# Altium schematic sheet stm32core.SchDoc
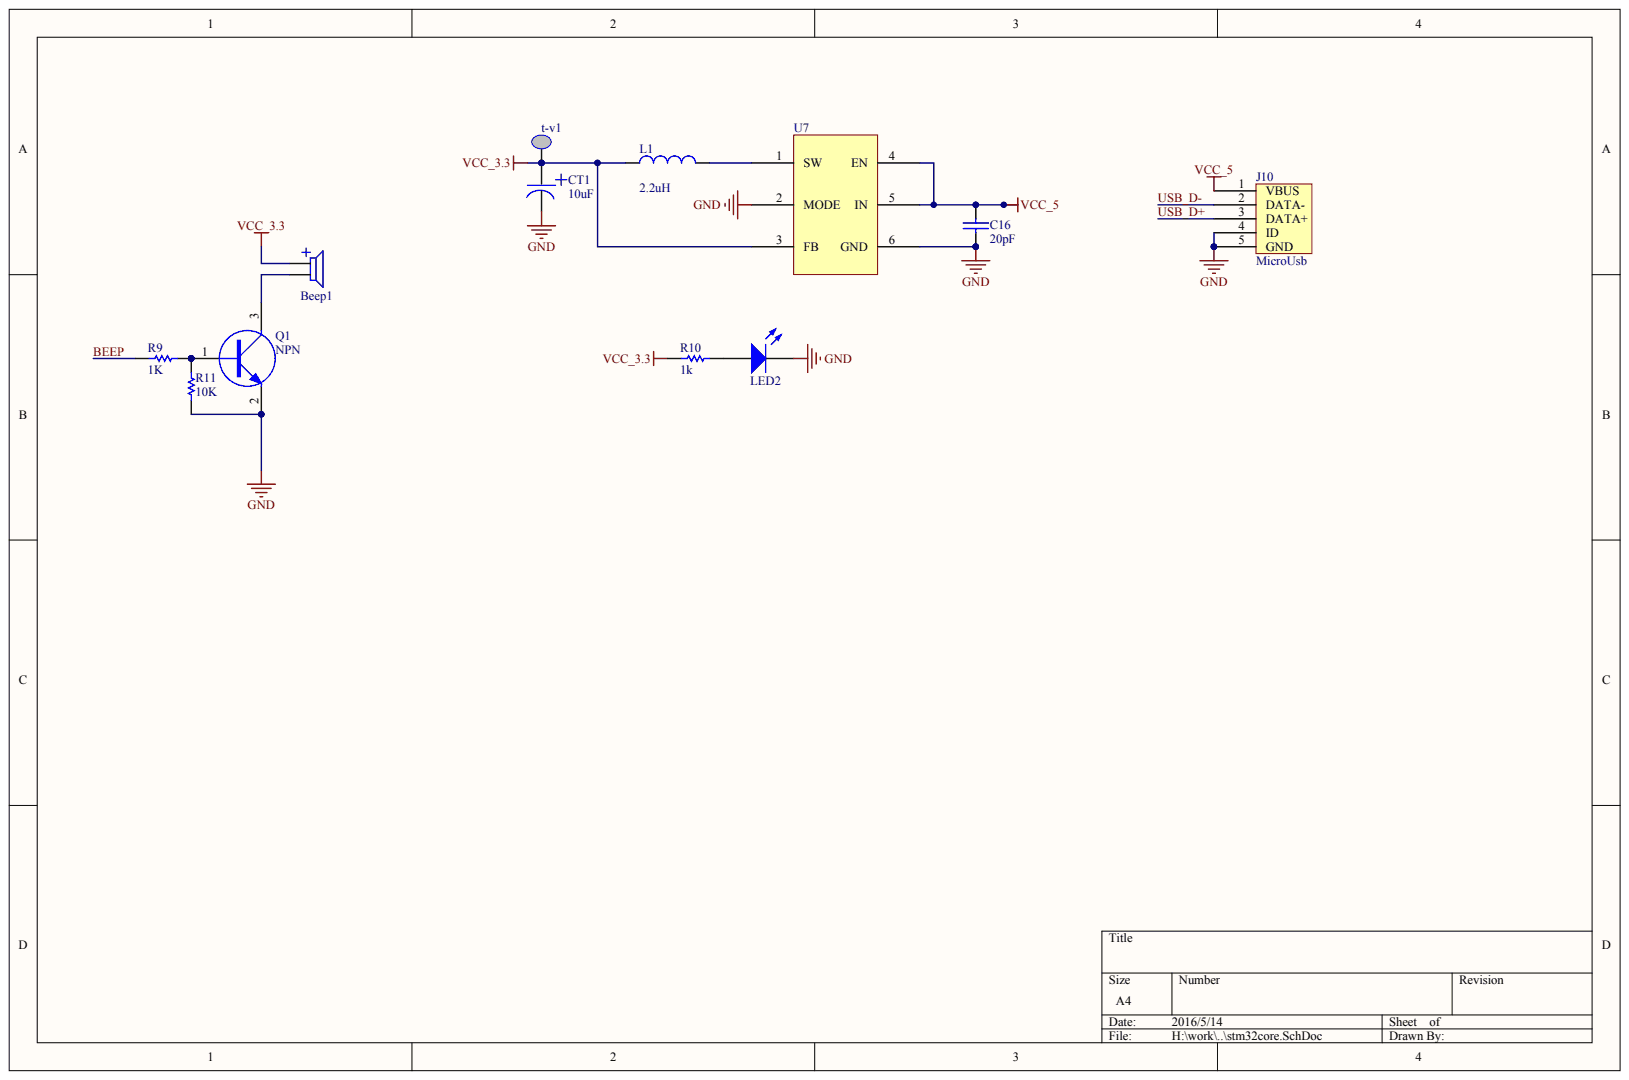
[diagram p0: stm32core.SchDoc]

## Parts
Beep1  Beep
C16  20pF
CT1  10uF
J10  MicroUsb
L1  2.2uH
LED2  LED
Q1  NPN
R9  1K
R10  1k
R11  10K
t-v1  TestPoint  (value not drawn)
U7  U?

## Nets
GND: C16.2 CT1.2 J10.4(ID) J10.5(GND) LED2.2 Q1.2 R11.1 U7.2(MODE) U7.6(GND)
VCC_3.3: Beep1.1 CT1.1 L1.1 R10.2 t-v1.1 U7.3(FB)
VCC_5: C16.1 J10.1(VBUS) U7.4(EN) U7.5(IN)
BEEP: R9.1
USB_D+: J10.3(DATA+)
USB_D-: J10.2(DATA-)
NetBeep1_2: Beep1.2 Q1.3
NetL1_2: L1.2 U7.1(SW)
NetLED2_1: LED2.1 R10.1
NetQ1_1: Q1.1 R9.2 R11.2
